# Altium schematic sheet MCU.SchDoc
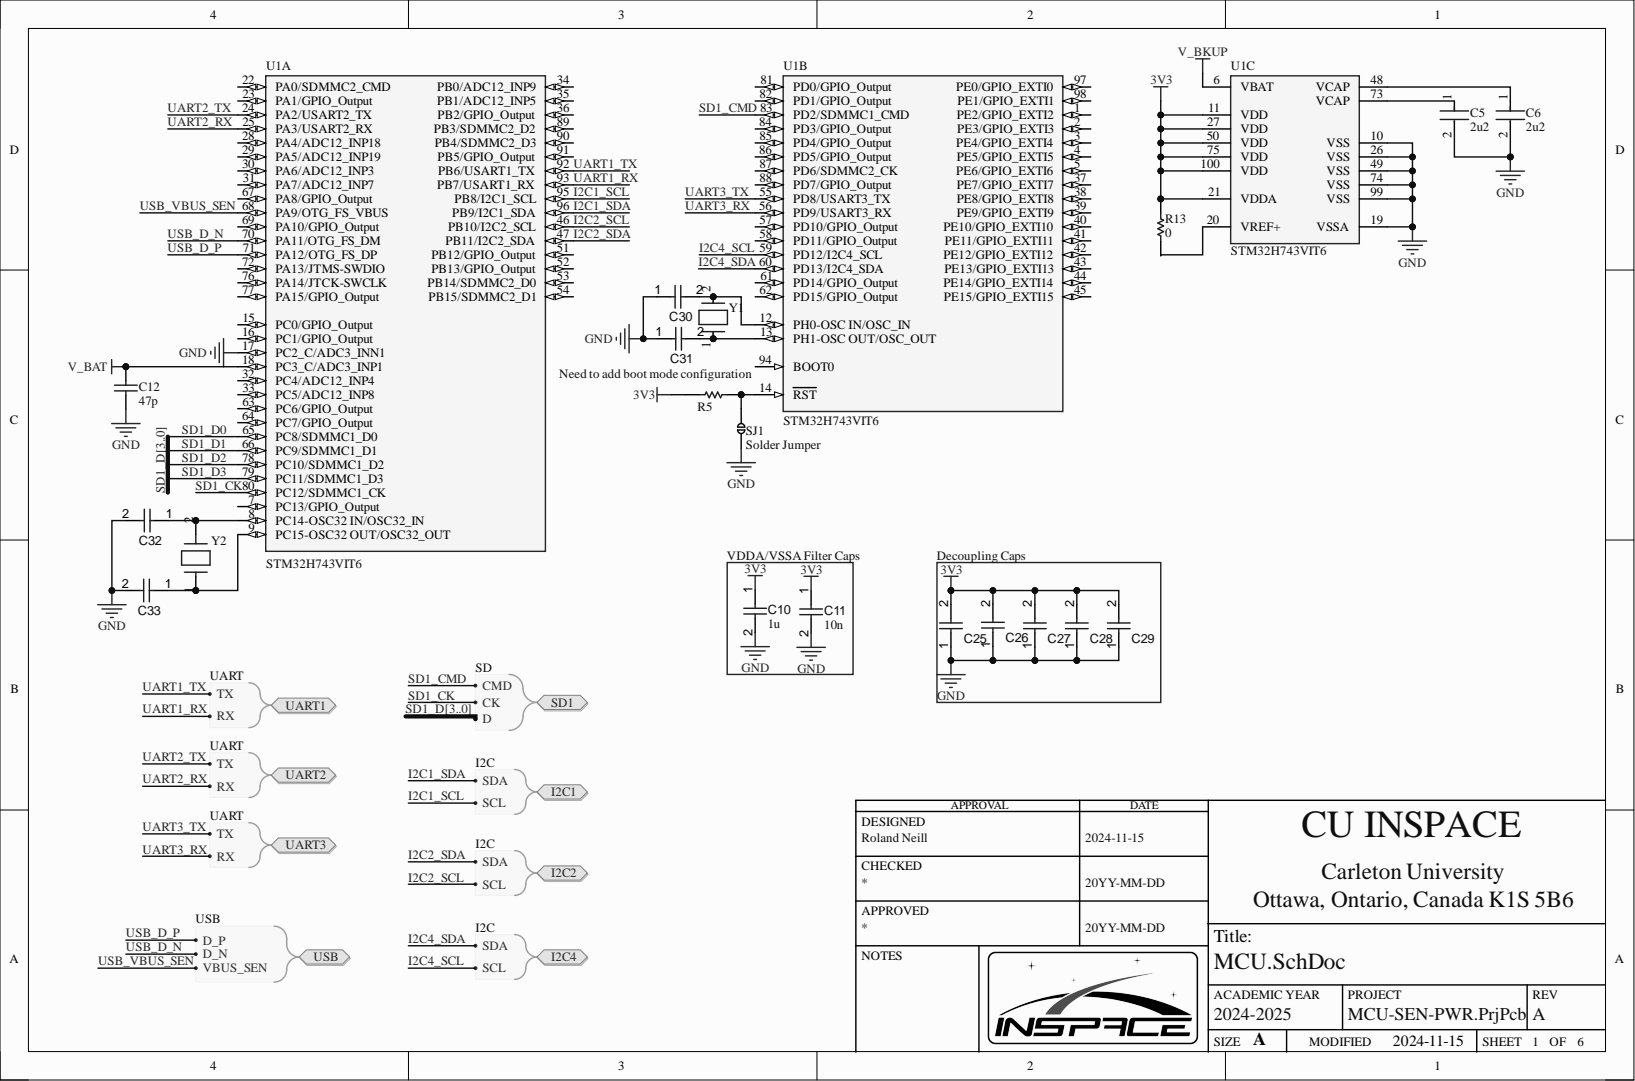
[diagram p0: MCU.SchDoc]

## Parts
C5  value 2u2  [FP-C2012-125-0_2-IPC_A]  (hidden comment: C2012X7R1H225K125AC)
C6  value 2u2  [FP-C2012-125-0_2-IPC_A]  (hidden comment: C2012X7R1H225K125AC)
C10  value 1u  [CAPC1608X90X35ML15T15]  (hidden comment: Capacitor 1uF +/- 10% 16V 0603)
C11  value 10n  [CAPC0402(1005)60_L]  (hidden comment: Capacitor 10nF +/-20% 50V 0402)
C12  value 47p  [CAPC1005X55X25LL05T05]  (hidden comment: VJ0402A470FXXCW1BC)
C25  Capacitors  (value not drawn)
C26  Capacitors  (value not drawn)
C27  Capacitors  (value not drawn)
C28  Capacitors  (value not drawn)
C29  Capacitors  (value not drawn)
C30  Capacitors,18pF  (value not drawn)
C31  Capacitors,18pF  (value not drawn)
C32  Capacitors,19pF  (value not drawn)
C33  Capacitors,19pF  (value not drawn)
R5  Resistors  (value not drawn)
R13  value 0  [RESC2013X60X35ML10T20]  (hidden comment: Resistor 0R +/- 5% 0805 125 mW)
SJ1  Solder Jumper  [Solder Jumper-Footprint-1]
U1  STM32H743VIT6  [FP-LQFP100-1L-MFG]  (parts A,B,C)
Y1  ECS-250-12-30-GN-TR  [FP-ECX-53-MFG]  (value not drawn)
Y2  ECS-.327-12.5-12R-TR  [FP-ECX-12R-MFG]  (value not drawn)

## Ports
I2C1, I2C1.SCL, I2C1.SDA, I2C2, I2C2.SCL, I2C2.SDA, I2C4, I2C4.SCL, I2C4.SDA, SD1, SD1.CK, SD1.CMD, SD1.D0, SD1.D1, SD1.D2, SD1.D3, SD1.D[3..0], UART1, UART1.RX, UART1.TX, UART2, UART2.RX, UART2.TX, UART3, UART3.RX, UART3.TX, USB, USB.D_N, USB.D_P, USB.VBUS_SEN

## Nets
3V3: C10.1 C11.1 C25.2 C26.2 C27.2 C28.2 C29.2 R5.1 R13.1 U1.11(VDD) U1.21(VDDA) U1.27(VDD) U1.50(VDD) U1.75(VDD) U1.100(VDD)
GND: C5.2 C6.2 C10.2 C11.2 C12.2 C25.1 C26.1 C27.1 C28.1 C29.1 C30.1 C31.1 C32.2 C33.2 SJ1.1 U1.10(VSS) U1.17(PC2_C/ADC3_INN1) U1.19(VSSA) U1.26(VSS) U1.49(VSS) U1.74(VSS) U1.99(VSS)
V_BAT: C12.1 U1.18(PC3_C/ADC3_INP1)
V_BKUP: U1.6(VBAT)
I2C1_SCL: U1.95(PB8/I2C1_SCL) ; port I2C1
I2C1_SDA: U1.96(PB9/I2C1_SDA) ; port I2C1
I2C2_SCL: U1.46(PB10/I2C2_SCL) ; port I2C2
I2C2_SDA: U1.47(PB11/I2C2_SDA) ; port I2C2
I2C4_SCL: U1.59(PD12/I2C4_SCL) ; port I2C4
I2C4_SDA: U1.60(PD13/I2C4_SDA) ; port I2C4
SD1_CK: U1.80(PC12/SDMMC1_CK) ; port SD1
SD1_CMD: U1.83(PD2/SDMMC1_CMD) ; port SD1
SD1_D0: U1.65(PC8/SDMMC1_D0) ; port SD1  [also labelled SD1_D]
SD1_D1: U1.66(PC9/SDMMC1_D1) ; port SD1  [also labelled SD1_D]
SD1_D2: U1.78(PC10/SDMMC1_D2) ; port SD1  [also labelled SD1_D]
SD1_D3: U1.79(PC11/SDMMC1_D3) ; port SD1  [also labelled SD1_D]
UART1_RX: U1.93(PB7/USART1_RX) ; port UART1
UART1_TX: U1.92(PB6/USART1_TX) ; port UART1
UART2_RX: U1.25(PA3/USART2_RX) ; port UART2
UART2_TX: U1.24(PA2/USART2_TX) ; port UART2
UART3_RX: U1.56(PD9/USART3_RX) ; port UART3
UART3_TX: U1.55(PD8/USART3_TX) ; port UART3
USB_D_N: U1.70(PA11/OTG_FS_DM) ; port USB
USB_D_P: U1.71(PA12/OTG_FS_DP) ; port USB
USB_VBUS_SEN: U1.68(PA9/OTG_FS_VBUS) ; port USB
NetC5_1: C5.1 U1.73(VCAP)
NetC6_1: C6.1 U1.48(VCAP)
NetC30_2: C30.2 U1.12(PH0-OSC_IN/OSC_IN) Y1.2
NetC31_2: C31.2 U1.13(PH1-OSC_OUT/OSC_OUT) Y1.1
NetC32_1: C32.1 U1.8(PC14-OSC32_IN/OSC32_IN) Y2.2
NetC33_1: C33.1 U1.9(PC15-OSC32_OUT/OSC32_OUT) Y2.1
NetR5_2: R5.2 SJ1.0 U1.14(RST)
NetR13_2: R13.2 U1.20(VREF+)

Not connected: U1 1(PE2/GPIO_EXTI2) 2(PE3/GPIO_EXTI3) 3(PE4/GPIO_EXTI4) 4(PE5/GPIO_EXTI5) 5(PE6/GPIO_EXTI6) 7(PC13/GPIO_Output) 15(PC0/GPIO_Output) 16(PC1/GPIO_Output) 22(PA0/SDMMC2_CMD) 23(PA1/GPIO_Output) 28(PA4/ADC12_INP18) 29(PA5/ADC12_INP19) 30(PA6/ADC12_INP3) 31(PA7/ADC12_INP7) 32(PC4/ADC12_INP4) 33(PC5/ADC12_INP8) 34(PB0/ADC12_INP9) 35(PB1/ADC12_INP5) 36(PB2/GPIO_Output) 37(PE7/GPIO_EXTI7) 38(PE8/GPIO_EXTI8) 39(PE9/GPIO_EXTI9) 40(PE10/GPIO_EXTI10) 41(PE11/GPIO_EXTI11) 42(PE12/GPIO_EXTI12) 43(PE13/GPIO_EXTI13) 44(PE14/GPIO_EXTI14) 45(PE15/GPIO_EXTI15) 51(PB12/GPIO_Output) 52(PB13/GPIO_Output) 53(PB14/SDMMC2_D0) 54(PB15/SDMMC2_D1) 57(PD10/GPIO_Output) 58(PD11/GPIO_Output) 61(PD14/GPIO_Output) 62(PD15/GPIO_Output) 63(PC6/GPIO_Output) 64(PC7/GPIO_Output) 67(PA8/GPIO_Output) 69(PA10/GPIO_Output) 72(PA13/JTMS-SWDIO) 76(PA14/JTCK-SWCLK) 77(PA15/GPIO_Output) 81(PD0/GPIO_Output) 82(PD1/GPIO_Output) 84(PD3/GPIO_Output) 85(PD4/GPIO_Output) 86(PD5/GPIO_Output) 87(PD6/SDMMC2_CK) 88(PD7/GPIO_Output) 89(PB3/SDMMC2_D2) 90(PB4/SDMMC2_D3) 91(PB5/GPIO_Output) 94(BOOT0) 97(PE0/GPIO_EXTI0) 98(PE1/GPIO_EXTI1)

## Library data of the parts
C5 C6 = CMP-001-00087-1: Multilayer Ceramic Capacitor, 2.2 uF, 50 V, ± 10%, X7R, 0805 [2012 Metric]; Voltage: 50V; Case Code (Imperial): 0805; Case Code (Metric): 2012; Case/Package: 0805; Component Kind: Standard; Construction: Flat; Depth: 1.25mm; Dielectric: X7R; Dielectric Material: Ceramic; Height: 1.25mm; Lead Free: Lead Free; Length: 2mm; Material: Ceramic; Max Operating Temperature: 125°C; Min Operating Temperature: -55°C; Mounting Technology: Surface Mount; Package Quantity: 1; Packaging: Tape and Reel; Radiation Hardening: No; Reach SVHC Compliant: No; RoHS Compliant: Yes; Series: C; Termination: SMD/SMT; Thickness: 0.057inch; Tolerance: 10%; Voltage Rating: 50V; Voltage Rating (DC): 50V; Weight: 0.000194oz; Width: 0.049inch; Library Name: Americas- CU InSpace-  Carleton University
C10 = CMP-001-00004-6: Chip Capacitor, 1 uF, +/- 10%, 16 V, 0603 (1608 Metric); Capacitor Type: Ceramic; Case/Package: 0603; Component Kind: Standard; Max Operating Temperature: 125°C; Min Operating Temperature: -55°C; Mounting Technology: SM; Pins: 2; RoHS Compliant: True; Tolerance: 10%; Voltage Rating: 16V; Library Name: Americas- CU InSpace-  Carleton University
C11 = CMP-001-00069-3: Chip Capacitor, 10nF +/-20%, 50V, 0402, Thickness 0.6 mm; Tolerance: 5%; Case/Package: 0402; Component Kind: Standard; Max Operating Temperature: 85°C; Min Operating Temperature: -55°C; Mounting Technology: SMT; Pins: 2; Voltage Rating: 50V; Library Name: Americas- CU InSpace-  Carleton University
C12 = CMP-001-00086-1: 0402 47 pF 25 V ±1 % Tolerance C0G/NP0 SMT Multilayer Ceramic Chip Capacitor; Dielectric: C0G; Dielectric Material: Tantalum; Dissipation Factor: 0.1%; Tolerance: 1%; Voltage Rating: 25V; Case Code (Imperial): 0402; Case Code (Metric): 1005; Case/Package: 0402; Component Kind: Standard; Construction: Flat; Depth: 0.5mm; Height: 0.55mm; Lead Free: Lead Free; Length: 1mm; Max Operating Temperature: 125°C; Min Operating Temperature: -55°C; Mounting Technology: Surface Mount; Package Quantity: 10000; Packaging: Tape and Reel; RoHS Compliant: Yes; Series: VJ; Termination: SMD/SMT; Voltage Rating (DC): 25V; Width: 0.5mm; Library Name: Americas- CU InSpace-  Carleton University
C30-C33 = Capacitors: Component Kind: Standard; Component Type: Capacitors; Library Name: Americas- CU InSpace-  Carleton University
  C30 C31: Value: 18pF
  C32 C33: Value: 19pF
R13 = CMP-009-00007-5: Chip Resistor, 0 Ohm, 0.125 W, -55 to 155 degC, 0805 (2012 Metric); Power: 125mW; Voltage Rating: 50V; Case/Package: 0805; Component Kind: Standard; Max Operating Temperature: 155°C; Min Operating Temperature: -55°C; Mounting Technology: SM; Pins: 2; RoHS Compliant: True; Tolerance: 5%; Library Name: Americas- CU InSpace-  Carleton University
SJ1 = CMP-00020-3: Component Kind: Standard; Library Name: Americas- CU InSpace-  Carleton University
U1 = CMP-020-00025-7: RISC Microcontroller, 32-Bit, FLASH, CORTEX-M7 CPU, 480MHz, CMOS, PQFP100; Component Kind: Standard; RoHS Compliant: Yes; Library Name: Americas- CU InSpace-  Carleton University
Y1 = CMP-003-00031-1: Crystal 25MHz 12pF 2-Pin SMD T/R; Component Kind: Standard; Library Name: Americas- CU InSpace-  Carleton University
Y2 = CMP-003-00030-1: Parallel - Fundamental Quartz Crystal, 0.032768MHz Nom; Component Kind: Standard; Library Name: Americas- CU InSpace-  Carleton University
C25-C29 = Capacitors: Component Kind: Standard; Component Type: Capacitors; Library Name: Americas- CU InSpace-  Carleton University
R5 = Resistors: Component Kind: Standard; Component Type: Resistors; Library Name: Americas- CU InSpace-  Carleton University
